# Altium schematic sheet IMX6UL_ALPHA_POWER.SchDoc(IMX6UL_ALPHA_POWER)
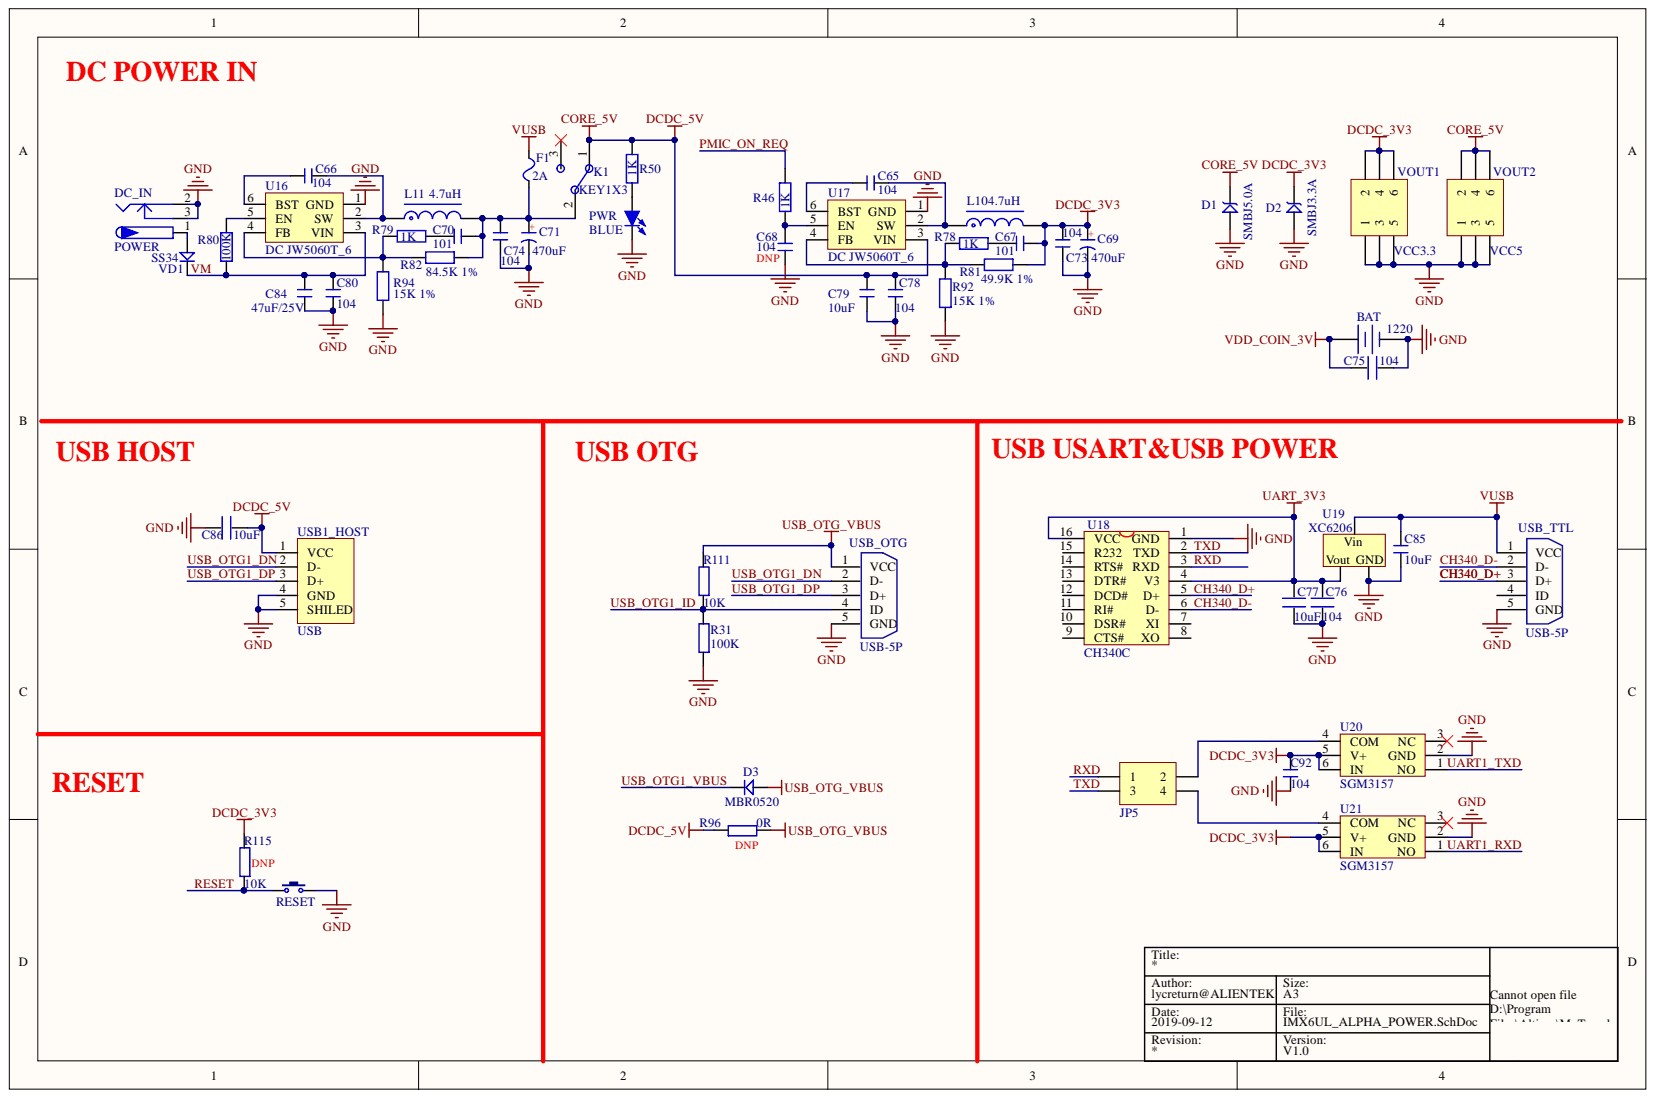
[diagram p0: IMX6UL_ALPHA_POWER.SchDoc]

## Parts
BAT  1220  [CR1220_B]
C65  104  [C 0603]
C66  104  [C 0603]
C67  101  [C 0603]
C68  104  [C 0603]
C69  470uF  [CM D(6.3*5.4)]
C70  101  [C 0603]
C71  470uF  [CM D(6.3*5.4)]
C73  104  [C 0603]
C74  104  [C 0603]
C75  104  [C 0603]
C76  104  [C 0603]
C77  10uF  [C 0805]
C78  104  [C 0603]
C79  10uF  [C 0805]
C80  104  [C 0603]
C84  47uF/25V  [C 1206]
C85  10uF  [C 0805]
C86  10uF  [C 0805]
C92  104  [C 0603]
D1  SMBJ5.0A  [SMBJ]
D2  SMBJ3.3A  [SMBJ]
D3  MBR0520  [SOD-123F]
DC_IN  POWER  [DC10B]
F1  2A  [FU 1206]
JP5  Header2X2  [HDR-2X2]  (value not drawn)
K1  KEY1X3  [PKEY]
L10  4.7uH  [L-SMD-0420]
L11  4.7uH  [L-SMD-0420]
PWR  BLUE  [LED 0805B]
R31  100K  [R 0603]
R46  1K  [R 0603]
R50  1K  [R 0603]
R78  1K  [R 0603]
R79  1K  [R 0603]
R80  100K  [R 0603]
R81  49.9K 1%  [R 0603]
R82  84.5K 1%  [R 0603]
R92  15K 1%  [R 0603]
R94  15K 1%  [R 0603]
R96  0R  [R 0603]
R111  10K  [R 0603]
R115  10K  [R 0603]
RESET  KEY  [TSW DIP-6*6*5 - 2]  (value not drawn)
U16  DC JW5060T_6  [SOT23-6N]
U17  DC JW5060T_6  [SOT23-6N]
U18  CH340C  [SOP16_N]
U19  XC6206  [SOT23-3N]
U20  SGM3157  [SOT363-6L]
U21  SGM3157  [SOT363-6L]
USB1_HOST  USB  [USB-A/S_E]
USB_OTG  USB-5P  [USB-MINI-A]
USB_TTL  USB-5P  [USB-MINI-A]
VD1  SS34  [SMA]
VOUT1  VCC3.3  [HDR2X3]
VOUT2  VCC5  [HDR2X3]

## Nets
CORE_5V: C78.2 C79.2 C86.1 D1.2 K1.1 R50.2 R96.1 U17.3(VIN) USB1_HOST.1(VCC) VOUT2.2 VOUT2.4 VOUT2.6
DCDC_3V3: C67.2 C69.1 C73.1 C92.2 D2.2 L10.2 R81.2 R115.2 U20.5(V+) U20.6(IN) U21.5(V+) U21.6(IN) VOUT1.2 VOUT1.4 VOUT1.6
GND: BAT.2 C68.1 C69.2 C71.2 C73.2 C74.2 C75.1 C76.1 C77.2 C78.1 C79.1 C80.1 C84.1 C85.1 C86.2 C92.1 D1.1 D2.1 DC_IN.2 DC_IN.3 PWR.2 R31.1 R92.2 R94.2 RESET.2 U16.1(GND) U17.1(GND) U18.1(GND) U19.1 U20.2(GND) U21.2(GND) USB1_HOST.4(GND) USB1_HOST.5(SHILED) USB_OTG.5(GND) USB_TTL.5(GND) VOUT1.1 VOUT1.3 VOUT1.5 VOUT2.1 VOUT2.3 VOUT2.5
UART_3V3: C76.2 C77.1 U18.4(V3) U18.16(VCC) U19.2
USB_OTG_VBUS: D3.1 R96.2 R111.2 USB_OTG.1(VCC)
VDD_COIN_3V: BAT.1 C75.2
VUSB: C85.2 F1.2 U19.3 USB_TTL.1(VCC)
CH340_D+: U18.5(D+) USB_TTL.3(D+)
CH340_D-: U18.6(D-) USB_TTL.2(D-)
PMIC_ON_REQ: R46.2
RESET: R115.1 RESET.1
RXD: JP5.1 U18.3(RXD)
TXD: JP5.3 U18.2(TXD)
UART1_RXD: U21.1(NO)
UART1_TXD: U20.1(NO)
USB_OTG1_DN: USB1_HOST.2(D-) USB_OTG.2(D-)
USB_OTG1_DP: USB1_HOST.3(D+) USB_OTG.3(D+)
USB_OTG1_ID: R31.2 R111.1 USB_OTG.4(ID)
USB_OTG1_VBUS: D3.2
VM: C80.2 C84.2 R80.2 U16.3(VIN) VD1.2
NetC65_1: C65.1 U17.6(BST)
NetC65_2: C65.2 L10.1 U17.2(SW)
NetC66_1: C66.1 U16.6(BST)
NetC66_2: C66.2 L11.1 U16.2(SW)
NetC67_1: C67.1 R78.2
NetC68_2: C68.2 R46.1 U17.5(EN)
NetC70_1: C70.1 R79.2
NetC70_2: C70.2 C71.1 C74.1 F1.1 K1.2 L11.2 R82.2
NetDC_IN_1: DC_IN.1 VD1.1
NetJP5_2: JP5.2 U20.4(COM)
NetJP5_4: JP5.4 U21.4(COM)
NetPWR_1: PWR.1 R50.1
NetR78_1: R78.1 R81.1 R92.1 U17.4(FB)
NetR79_1: R79.1 R82.1 R94.1 U16.4(FB)
NetR80_1: R80.1 U16.5(EN)

Not connected: K1 3; U18 7(XI) 8(XO) 9(CTS#) 10(DSR#) 11(RI#) 12(DCD#) 13(DTR#) 14(RTS#) 15(R232); U20 3(NC); U21 3(NC); USB_TTL 4(ID)

## Library data of the parts
BAT = CR-3V: 微型电池; 版权归属: 源创客; BOM名称: 微型电池; Component Kind: Standard; Library Name: 未分类器件.SchLib; 学习资源: www.ooocke.com
C65-C68 C70 C73 C74 C78-C80 C84 C85 C92 = C: 无极性贴片电容; 版权归属: 源创客; BOM名称: 无极性贴片电容; Component Kind: Standard; 学习资源: www.ooocke.com
  C65-C68 C70 C73 C74 C78-C80 C84 C85: Library Name: 电阻-电容-电感.SchLib
  C92: Library Name: Lycreturn.IntLib
C69 C71 = C-CM: 贴片电解电容; 版权归属: 源创客; BOM名称: 贴片电解电容; Component Kind: Standard; Library Name: 电阻-电容-电感.SchLib; 学习资源: www.ooocke.com
C75-C77 C86 = C: Component Kind: Standard; Library Name: 电阻-电容-电感.SchLib
D1 D2 = SMBJ: TVS; Component Kind: Standard; Library Name: 阿波罗STM32F4&F7底板封装库.IntLib
D3 VD1 = SS14: 肖特基二极管; 属性: 40V/1A; 版权归属: 源创客; BOM名称: 肖特基二极管; Component Kind: Standard; Library Name: 二极管-整流桥.SchLib; 学习资源: www.ooocke.com
DC_IN = P-DC: 低压电源接口; BOM名称: DC电源接口; 版权归属: 源创客; Component Kind: Standard; Library Name: 接口类.SchLib; 学习资源: www.ooocke.com
F1 = FU: 贴片保险丝; 版权归属: 源创客; BOM名称: 贴片保险丝; Component Kind: Standard; Library Name: 保险丝-晶振.SchLib; 学习资源: www.ooocke.com
JP5 = Header 2X2: 2*2P接插件; 版权归属: 源创客; BOM名称: 2*2P接插件; Component Kind: Standard; Library Name: 接插件.SchLib; 学习资源: www.ooocke.com
K1 = PKEY: Component Kind: Standard; Library Name: ZZK_COMPONENT.IntLib
L10 L11 = L-CDRH: 功率屏蔽电感; 版权归属: 源创客; BOM名称: 功率屏蔽电感; Component Kind: Standard; Library Name: 电阻-电容-电感.SchLib; 学习资源: www.ooocke.com
PWR = LED-SMD: 贴片LED; 版权归属: 源创客; BOM名称: 贴片LED; Component Kind: Standard; Library Name: LED.SchLib; 学习资源: www.ooocke.com
R31 R96 R111 R115 = R: Component Kind: Standard; Library Name: 电阻-电容-电感.SchLib
R46 R50 R78-R82 R92 R94 = R: 贴片电阻; 版权归属: 源创客; BOM名称: 贴片电阻; Component Kind: Standard; Library Name: 电阻-电容-电感.SchLib; 学习资源: www.ooocke.com
RESET = KEY: 按键; BOM名称: 3x6轻触开关; 版权归属: 源创客; Component Kind: Standard; Library Name: 按键-开关.SchLib; 学习资源: www.ooocke.com
U16 U17 = DC JW5060T_6: DC降压芯片; Component Kind: Standard; Library Name: LDO和DCDC.SchLib
U18 = CH340G: USB2UART; Component Kind: Standard; Library Name: ALIENTEK_COMPONENT.IntLib
U19 = LDO XC6206: LDO; Component Kind: Standard; ComponentLink1Description: 供应商链接; ComponentLink1URL: http://www.szlcsc.com/product/details_5896.html; Library Name: LDO和DCDC.SchLib; Package: SOT-23; Supplier: LC; SuppliersPartNumber: C5446
U20 U21 = SGM3157: 单路双掷模拟开关; Component Kind: Standard; Library Name: IMX6ULL_BASE_V1.1.IntLib
USB1_HOST = USB-A/S: USB-A型母接口; 版权归属: 源创客; BOM名称: USB-A型母接口; Component Kind: Standard; Library Name: 接口类.SchLib; 学习资源: www.ooocke.com
USB_OTG USB_TTL = USB-5P: 微型USB母座; 版权归属: 源创客; BOM名称: 微型USB母座; Component Kind: Standard; Library Name: 接口类.SchLib; 学习资源: www.ooocke.com
VOUT1 VOUT2 = Header 3X2: Header, 3-Pin, Dual row; Component Kind: Standard; LatestRevisionDate: 17-Jul-2002; LatestRevisionNote: Re-released for DXP Platform.; Library Name: Miscellaneous Connectors.IntLib; Signal Integrity: Connector; Publisher: Altium Limited
